# Altium schematic sheet Pico.SchDoc(Pico)
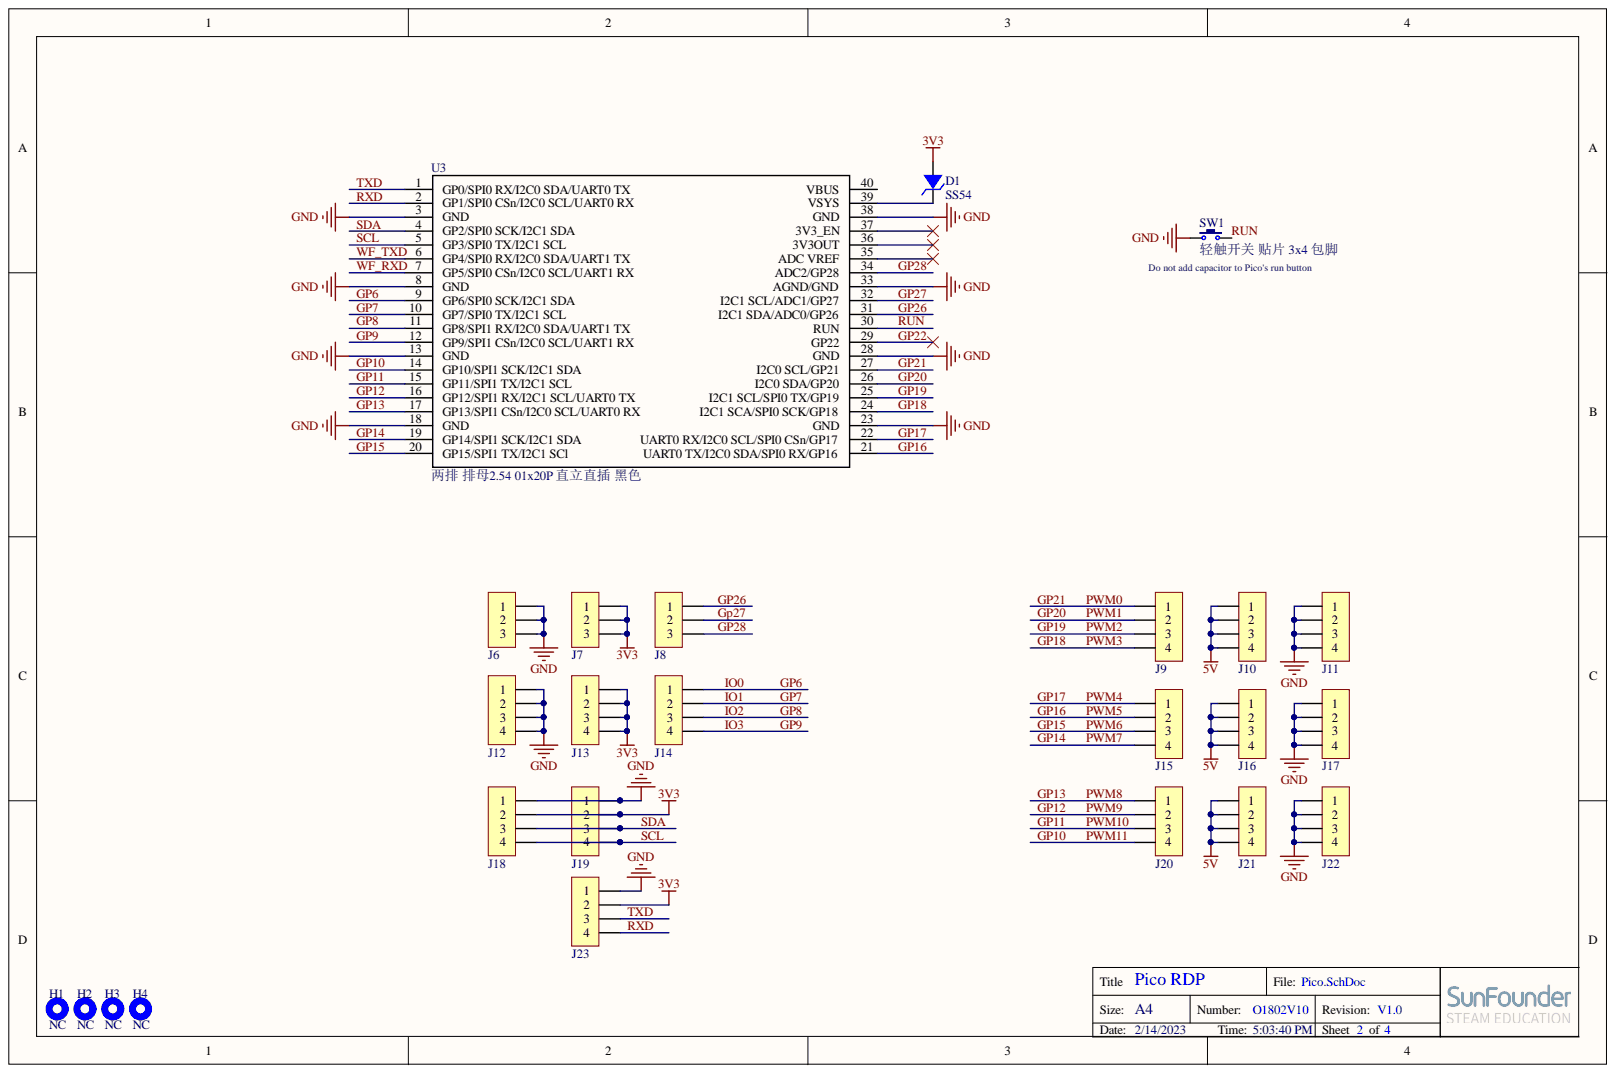
[diagram p0: Pico.SchDoc]

## Parts
D1  SS54  [SMA]
H1  NC  [PCB 固定孔 M2.5]
H2  NC  [PCB 固定孔 M2.5]
H3  NC  [PCB 固定孔 M2.5]
H4  NC  [PCB 固定孔 M2.5]
J6  排针2.54 01x03P 直立 直插 黑色  [排针2.54 01x03P 直立 直插 黑色]  (value not drawn)
J7  排针2.54 01x03P 直立 直插 红色  [排针2.54 01x03P 直立 直插 红色]  (value not drawn)
J8  排针2.54 01x03P 直立 直插 黄色  [排针2.54 01x03P 直立 直插 黄色]  (value not drawn)
J9  排针2.54 01x04P 直立 直插 黄色  [排针2.54 01x04P 直立 直插 黄色]  (value not drawn)
J10  排针2.54 01x04P 直立 直插 红色  [排针2.54 01x04P 直立 直插 红色]  (value not drawn)
J11  排针2.54 01x04P 直立 直插 黑色  [排针2.54 01x04P 直立 直插 黑色]  (value not drawn)
J12  排针2.54 01x04P 直立 直插 黑色  [排针2.54 01x04P 直立 直插 黑色]  (value not drawn)
J13  排针2.54 01x04P 直立 直插 红色  [排针2.54 01x04P 直立 直插 红色]  (value not drawn)
J14  排针2.54 01x04P 直立 直插 黄色  [排针2.54 01x04P 直立 直插 黄色]  (value not drawn)
J15  排针2.54 01x04P 直立 直插 黄色  [排针2.54 01x04P 直立 直插 黄色]  (value not drawn)
J16  排针2.54 01x04P 直立 直插 红色  [排针2.54 01x04P 直立 直插 红色]  (value not drawn)
J17  排针2.54 01x04P 直立 直插 黑色  [排针2.54 01x04P 直立 直插 黑色]  (value not drawn)
J18  排针2.54 01x04P 直立 直插 黑色  [排针2.54 01x04P 直立 直插 黑色]  (value not drawn)
J19  4P  [SH1.0 4P 贴片 卧式]  (value not drawn)
J20  排针2.54 01x04P 直立 直插 黄色  [排针2.54 01x04P 直立 直插 黄色]  (value not drawn)
J21  排针2.54 01x04P 直立 直插 红色  [排针2.54 01x04P 直立 直插 红色]  (value not drawn)
J22  排针2.54 01x04P 直立 直插 黑色  [排针2.54 01x04P 直立 直插 黑色]  (value not drawn)
J23  排针2.54 01x04P 直立 直插 黑色  [排针2.54 01x04P 直立 直插 黑色]  (value not drawn)
SW1  轻触开关 贴片 3x4 包脚  [轻触开关 贴片 3x4 包脚]
U3  两排 排母2.54 01x20P 直立直插 黑色  [Raspberry Pi Pico_THOUGH_HOLE]

## Nets
3V3: D1.1 J7.1 J7.2 J7.3 J13.1 J13.2 J13.3 J13.4 J18.2 J19.2 J23.2
5V: J10.1 J10.2 J10.3 J10.4 J16.1 J16.2 J16.3 J16.4 J21.1 J21.2 J21.3 J21.4
GND: J6.1 J6.2 J6.3 J11.1 J11.2 J11.3 J11.4 J12.1 J12.2 J12.3 J12.4 J17.1 J17.2 J17.3 J17.4 J18.1 J19.1 J22.1 J22.2 J22.3 J22.4 J23.1 SW1.1 U3.3(GND) U3.8(GND) U3.13(GND) U3.18(GND) U3.23(GND) U3.28(GND) U3.33(AGND/GND) U3.38(GND)
GP6: J14.1 U3.9(GP6/SPI0_SCK/I2C1_SDA)
GP7: J14.2 U3.10(GP7/SPI0_TX/I2C1_SCL)
GP8: J14.3 U3.11(GP8/SPI1_RX/I2C0_SDA/UART1_TX)
GP9: J14.4 U3.12(GP9/SPI1_CSn/I2C0_SCL/UART1_RX)
GP10: J20.4 U3.14(GP10/SPI1_SCK/I2C1_SDA)
GP11: J20.3 U3.15(GP11/SPI1_TX/I2C1_SCL)
GP12: J20.2 U3.16(GP12/SPI1_RX/I2C1_SCL/UART0_TX)
GP13: J20.1 U3.17(GP13/SPI1_CSn/I2C0_SCL/UART0_RX)
GP14: J15.4 U3.19(GP14/SPI1_SCK/I2C1_SDA)
GP15: J15.3 U3.20(GP15/SPI1_TX/I2C1_SCl)
GP16: J15.2 U3.21(UART0_TX/I2C0_SDA/SPI0_RX/GP16)
GP17: J15.1 U3.22(UART0_RX/I2C0_SCL/SPI0_CSn/GP17)
GP18: J9.4 U3.24(I2C1_SCA/SPI0_SCK/GP18)
GP19: J9.3 U3.25(I2C1_SCL/SPI0_TX/GP19)
GP20: J9.2 U3.26(I2C0_SDA/GP20)
GP21: J9.1 U3.27(I2C0_SCL/GP21)
GP22: U3.29(GP22)
GP26: J8.1 U3.31(I2C1_SDA/ADC0/GP26)
GP27: J8.2 U3.32(I2C1_SCL/ADC1/GP27)
GP28: J8.3 U3.34(ADC2/GP28)
RUN: SW1.2 U3.30(RUN)
RXD: J23.4 U3.2(GP1/SPI0_CSn/I2C0_SCL/UART0_RX)
SCL: J18.4 J19.4 U3.5(GP3/SPI0_TX/I2C1_SCL)
SDA: J18.3 J19.3 U3.4(GP2/SPI0_SCK/I2C1_SDA)
TXD: J23.3 U3.1(GP0/SPI0_RX/I2C0_SDA/UART0_TX)
WF_RXD: U3.7(GP5/SPI0_CSn/I2C0_SCL/UART1_RX)
WF_TXD: U3.6(GP4/SPI0_RX/I2C0_SDA/UART1_TX)
NetD1_2: D1.2 U3.39(VSYS)

Not connected: U3 35(ADC_VREF) 36(3V3OUT) 37(3V3_EN) 40(VBUS)

## Library data of the parts
D1 = 二极管: 肖特基二极管; 属性: 40V/1A; 版权归属: 源创客; BOM名称: 肖特基二极管; Component Kind: Standard; 学习资源: www.ooocke.com; Library Name: ESP32 RDP.SchLib
H1-H4 = MountingHole: Component Kind: Standard; Library Name: SunFounder_Library.IntLib
J6-J8 = Header 3: 3P接插件; 版权归属: 源创客; BOM名称: 3P接插件; Component Kind: Standard; Library Name: ESP32 HAT.SchLib; 学习资源: www.ooocke.com
J9-J23 = Header 4: 4P接插件; 版权归属: 源创客; BOM名称: 4P接插件; Component Kind: Standard; Library Name: ESP32 HAT.SchLib; 学习资源: www.ooocke.com
SW1 = TSW SMD: 轻触开关; BOM名称: 2脚轻触开关; 版权归属: 源创客; Component Kind: Standard; Library Name: ESP32 HAT.SchLib; 学习资源: www.ooocke.com
U3 = Raspberry Pi Pico: Component Kind: Standard; Library Name: Schlib1.SchLib
